# Altium schematic sheet FPGA_Config.SchDoc
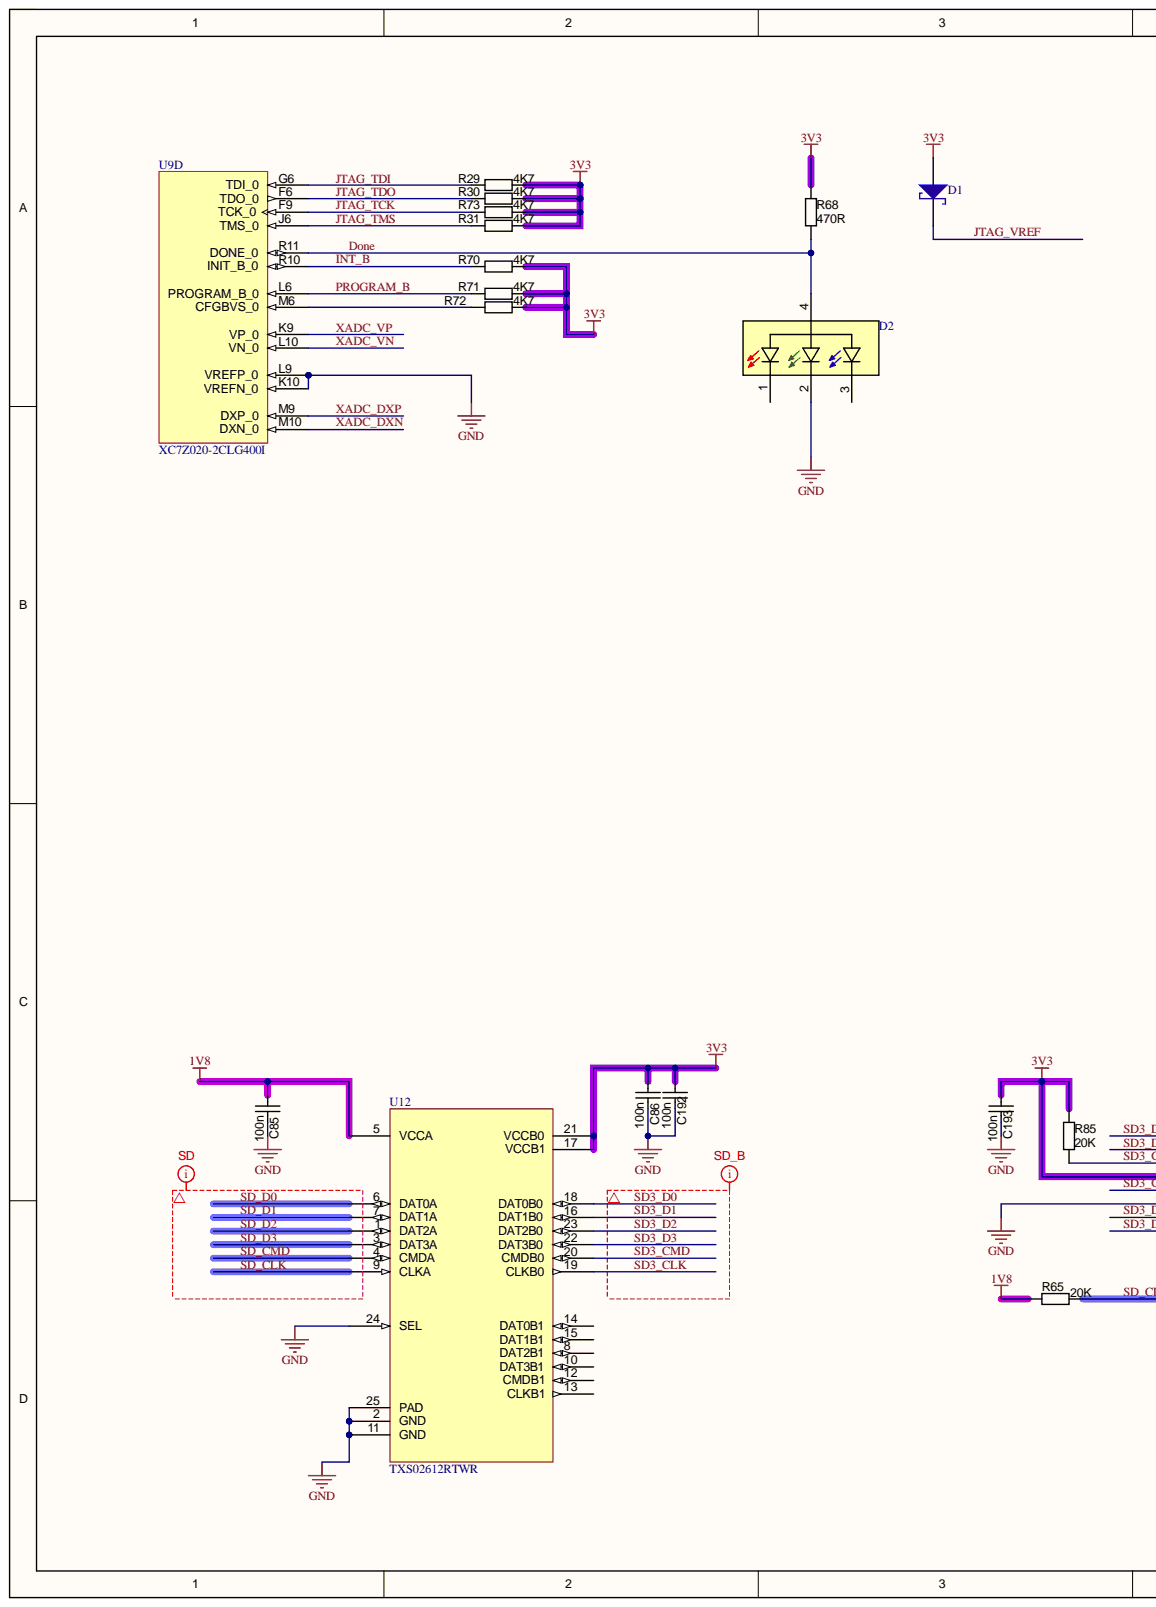
[diagram p0: FPGA_Config.SchDoc, left]
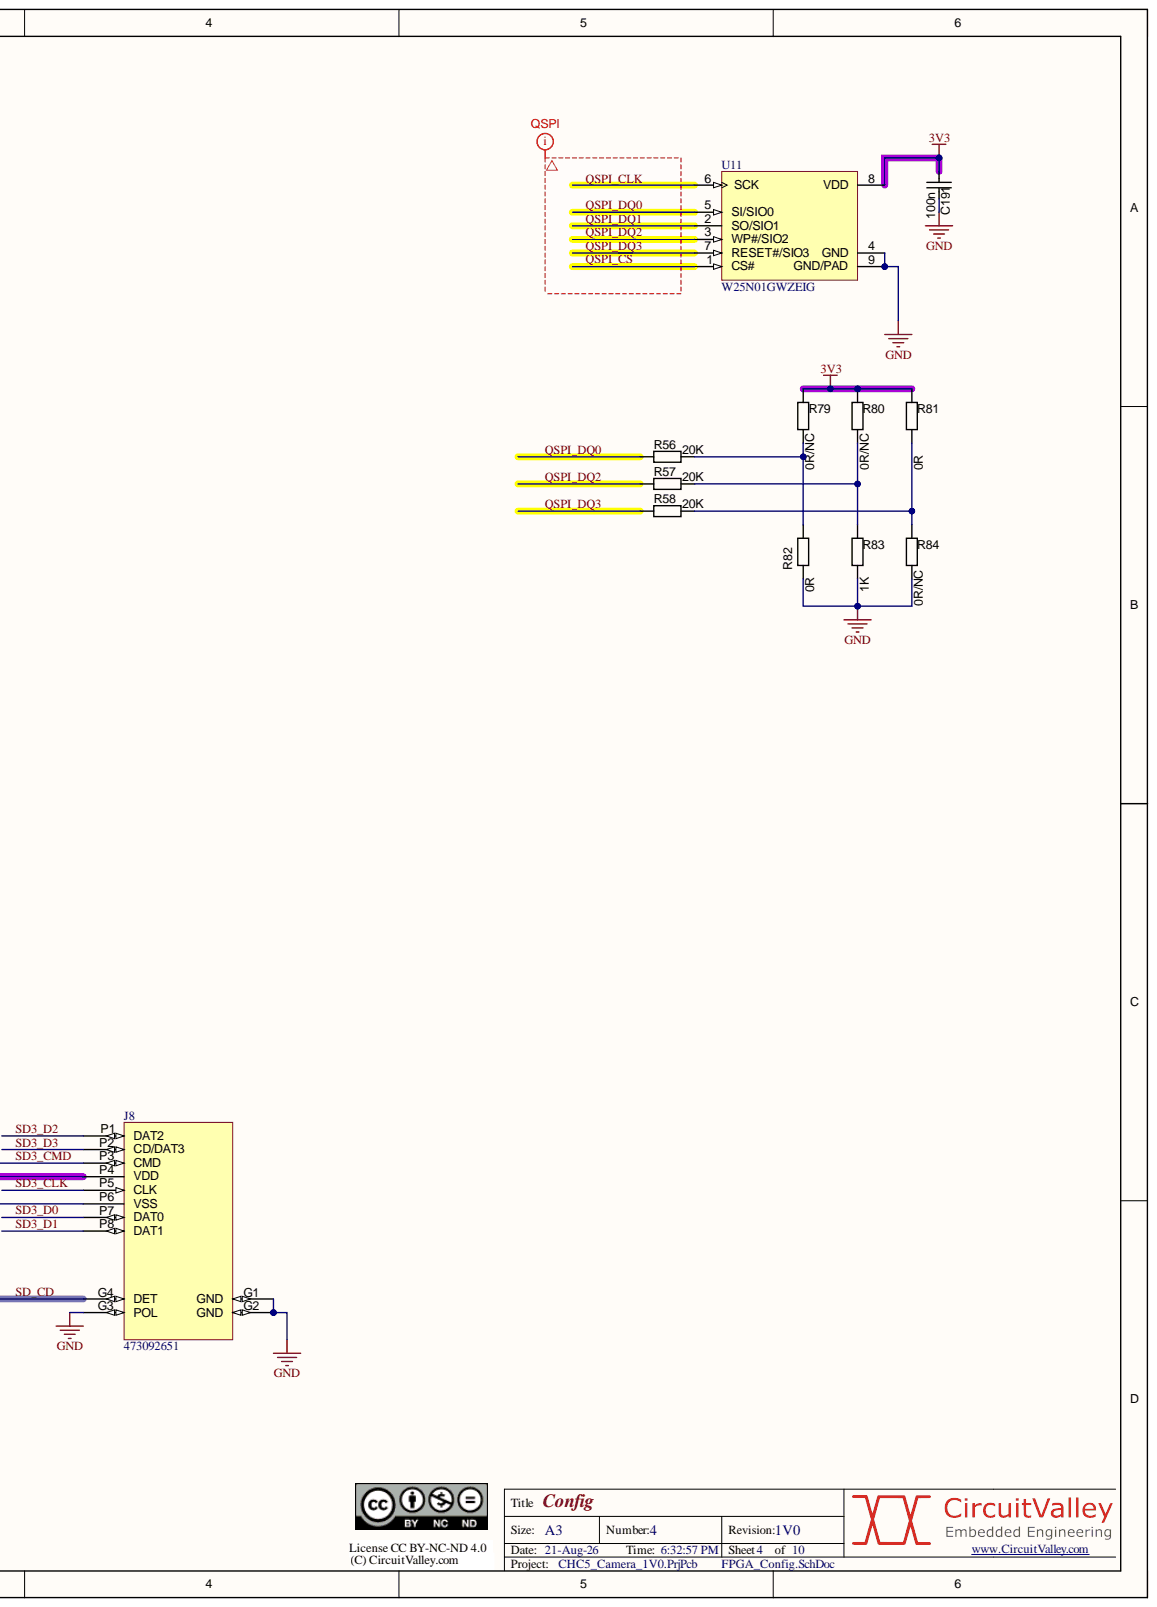
[diagram p1: FPGA_Config.SchDoc, right]

## Parts
C85  100n  [CAPC1005N]
C86  100n  [CAPC1005N]
C191  100n  [CAPC1005N]
C192  100n  [CAPC1005N]
C193  100n  [CAPC1005N]
D1  RB161QS-40  [SMD1006]  (value not drawn)
D2  LTST-C19HE1WT  [0606]  (value not drawn)
J8  473092651  [uSD_473092651]
R29  value 4K7  [RESC1005N]  (hidden comment: Thick Film)
R30  value 4K7  [RESC1005N]  (hidden comment: Thick Film)
R31  value 4K7  [RESC1005N]  (hidden comment: Thick Film)
R56  value 20K  [RESC1005N]  (hidden comment: Thick Film)
R57  value 20K  [RESC1005N]  (hidden comment: Thick Film)
R58  value 20K  [RESC1005N]  (hidden comment: Thick Film)
R65  value 20K  [RESC1005N]  (hidden comment: Thick Film)
R68  value 470R  [RESC1005N]  (hidden comment: Thick Film)
R70  value 4K7  [RESC1005N]  (hidden comment: Thick Film)
R71  value 4K7  [RESC1005N]  (hidden comment: Thick Film)
R72  value 4K7  [RESC1005N]  (hidden comment: Thick Film)
R73  value 4K7  [RESC1005N]  (hidden comment: Thick Film)
R79  value 0R/NC  [RESC1005N]  (hidden comment: Thick Film)
R80  value 0R/NC  [RESC1005N]  (hidden comment: Thick Film)
R81  value 0R  [RESC1005N]  (hidden comment: Thick Film)
R82  value 0R  [RESC1005N]  (hidden comment: Thick Film)
R83  value 1K  [RESC1005N]  (hidden comment: Thick Film)
R84  value 0R/NC  [RESC1005N]  (hidden comment: Thick Film)
R85  value 20K  [RESC1005N]  (hidden comment: Thick Film)
U9  XC7Z020-2CLG400I  [CLG400]  (part D)
U11  W25N01GWZEIG  [WSON8_8x6]
U12  TXS02612RTWR  [QFN24]

## Nets
1V8: C85.2 R65.1 U12.5(VCCA)
3V3: C86.2 C191.2 C192.2 C193.2 D1.1 J8.P4(VDD) R29.2 R30.2 R31.2 R68.2 R70.2 R71.2 R72.2 R73.2 R79.2 R80.2 R81.2 R85.2 U11.8(VDD) U12.17(VCCB1) U12.21(VCCB0)
GND: C85.1 C86.1 C191.1 C192.1 C193.1 D2.2 J8.G1(GND) J8.G2(GND) J8.G3(POL) J8.P6(VSS) R82.1 R83.1 R84.1 U9.K10(VREFN_0) U9.L9(VREFP_0) U11.4(GND) U11.9(GND/PAD) U12.2(GND) U12.11(GND) U12.24(SEL) U12.25(PAD)
Done: D2.4 R68.1 U9.R11(DONE_0)
INT_B: R70.1 U9.R10(INIT_B_0)
JTAG_TCK: R73.1 U9.F9(TCK_0)
JTAG_TDI: R29.1 U9.G6(TDI_0)
JTAG_TDO: R30.1 U9.F6(TDO_0)
JTAG_TMS: R31.1 U9.J6(TMS_0)
JTAG_VREF: D1.2
PROGRAM_B: R71.1 U9.L6(PROGRAM_B_0)
QSPI_~{CS}: U11.1(CS#)
QSPI_CLK: U11.6(SCK)
QSPI_DQ0: R56.1 U11.5(SI/SIO0)
QSPI_DQ1: U11.2(SO/SIO1)
QSPI_DQ2: R57.1 U11.3(WP#/SIO2)
QSPI_DQ3: R58.1 U11.7(RESET#/SIO3)
SD3_CLK: J8.P5(CLK) U12.19(CLKB0)
SD3_CMD: J8.P3(CMD) R85.1 U12.20(CMDB0)
SD3_D0: J8.P7(DAT0) U12.18(DAT0B0)
SD3_D1: J8.P8(DAT1) U12.16(DAT1B0)
SD3_D2: J8.P1(DAT2) U12.23(DAT2B0)
SD3_D3: J8.P2(CD/DAT3) U12.22(DAT3B0)
SD_CD: J8.G4(DET) R65.2
SD_CLK: U12.9(CLKA)
SD_CMD: U12.4(CMDA)
SD_D0: U12.6(DAT0A)
SD_D1: U12.7(DAT1A)
SD_D2: U12.1(DAT2A)
SD_D3: U12.3(DAT3A)
XADC_DXN: U9.M10(DXN_0)
XADC_DXP: U9.M9(DXP_0)
XADC_VN: U9.L10(VN_0)
XADC_VP: U9.K9(VP_0)
NetR56_2: R56.2 R79.1 R82.2
NetR57_2: R57.2 R80.1 R83.2
NetR58_2: R58.2 R81.1 R84.2
NetR72_1: R72.1 U9.M6(CFGBVS_0)

Not connected: D2 1 3; U12 8(DAT2B1) 10(DAT3B1) 12(CMDB1) 13(CLKB1) 14(DAT0B1) 15(DAT1B1)

## Library data of the parts
C85 C86 C191-C193 = CAPC_10n_0402_16V_10%: Capacitor SMD Cer 10nF 10% 16V X7R 0402; Manufacturer 1: AVX Corporation; Manufacturer Part Number 1: 0402YC103KAT2A; Ratings: 16V; X7R; Component Kind: Standard; ComponentLink1Description: http://www.avx.com/docs/Catalogs/cx7r.pdf; ComponentLink1URL: http://www.avx.com/docs/Catalogs/cx7r.pdf; ComponentLink2Description: http://www.yageo.com/documents/recent/UPY-GPHC_X7R_6.3V-to-50V_8.pdf; ComponentLink2URL: http://www.yageo.com/documents/recent/UPY-GPHC_X7R_6.3V-to-50V_8.pdf; Library Name: PBL - Capacitors.SCHLIB; Manufacturer 2: Yageo; Manufacturer Part Number 2: CC0402KRX7R7BB103; Operating Temperature: -55°C ~ 125°C; Package / Case: 0402 (1005 Metric); Size / Dimension: 0.039" L x 0.020" W (1.00mm x 0.50mm); Supplier 1: Digi-Key; Supplier 2: Digi-Key; Supplier Part Number 1: 478-1114-1-ND; Supplier Part Number 2: 311-1042-1-ND; Tolerance: ±10%; Value: 100n
D1 = Diode: Component Kind: Standard; Description: Diode; Library Name: cvlib.SchLib
D2 = RGBLED: Component Kind: Standard; Description: LTST-C19HE1WT; Library Name: cvlib.SchLib
J8 = uSD_473092651: Component Kind: Standard; Library Name: cvlib.SchLib
R29-R31 R56-R58 R65 R68 R70-R73 R79-R85 = RES_3K3_0402_5%: Resistor SMD chip 3.3k Ohm 0.063W 5% 0402; Manufacturer 1: Yageo; Manufacturer Part Number 1: RC0402JR-073K3L; Ratings: 1/16 W; Component Kind: Standard; ComponentLink1Description: http://www.yageo.com/documents/recent/PYu-RC0402_51_RoHS_L_6.pdf; ComponentLink1URL: http://www.yageo.com/documents/recent/PYu-RC0402_51_RoHS_L_6.pdf; Library Name: PBL - Resistors.SCHLIB; Material: Thick Film; Package / Case: 0402 (1005 metric); Supplier 1: Digi-Key; Supplier Part Number 1: 311-3.3KJRCT-ND; Tolerance: ±5%
U9 = XC7Z020-2CLG400I: 733 MHz Zynq™-7000 AP SoC, 130 PS I/O, 125 FPGA I/O, 400-Ball BGA, Speed Grade 2, Industrial Grade, Pb-Free; Manufacturer: Xilinx; Extensible Block RAM (# 36Kb Blocks): 560 KB (140); Flip Flops: 106400; LUTs: 53200; Speed Grade: -2; Code_JEDEC: MO-275-MMAA-1; Component Kind: Standard; ComponentLink1Description: Datasheet; ComponentLink1URL: http://www.xilinx.com/support/documentation/data_sheets/ds190-Zynq-7000-Overview.pdf; ComponentLink2Description: Package Specification; ComponentLink2URL: http://www.xilinx.com/support/documentation/user_guides/ug865-Zynq-7000-Pkg-Pinout.pdf; ComponentLink3Description: DC and AC Switching Characteristics; ComponentLink3URL: http://www.xilinx.com/support/documentation/data_sheets/ds187-XC7Z010-XC7Z020-Data-Sheet.pdf; ComponentLink4Description: Package File; ComponentLink4URL: http://www.xilinx.com/support/packagefiles/z7packages/xc7z020clg400pkg.txt; ComponentLink5Description: Packaging and Pinout; ComponentLink5URL: http://www.xilinx.com/support/documentation/user_guides/ug865-Zynq-7000-Pkg-Pinout.pdf; ComponentLink6Description: PCB and Layout Guide; ComponentLink6URL: http://www.xilinx.com/support/documentation/user_guides/ug483_7Series_PCB.pdf; DatasheetVersion: v1.2; Generic Part Number: XC7Z020; Library Name: Xilinx Zynq-7000.SchLib; Mounting Technology: Surface Mount; PackageDescription: Wire-Bond Chip-Scale BGA, Body 17 x 17 mm, 0.8 mm Pitch; PackageReference: CLG400; PackageVersion: Rev 1.1; PartNumber: XC7Z020-2CLG400I; RoHS: Pb-Free
U11 = W25N01GWZEIG: Component Kind: Standard; Library Name: cvlib.SchLib
U12 = TXS02612RTWR: Component Kind: Standard; Library Name: cvlib.SchLib
